# Altium schematic sheet A-Headers.SchDoc(A-Headers)
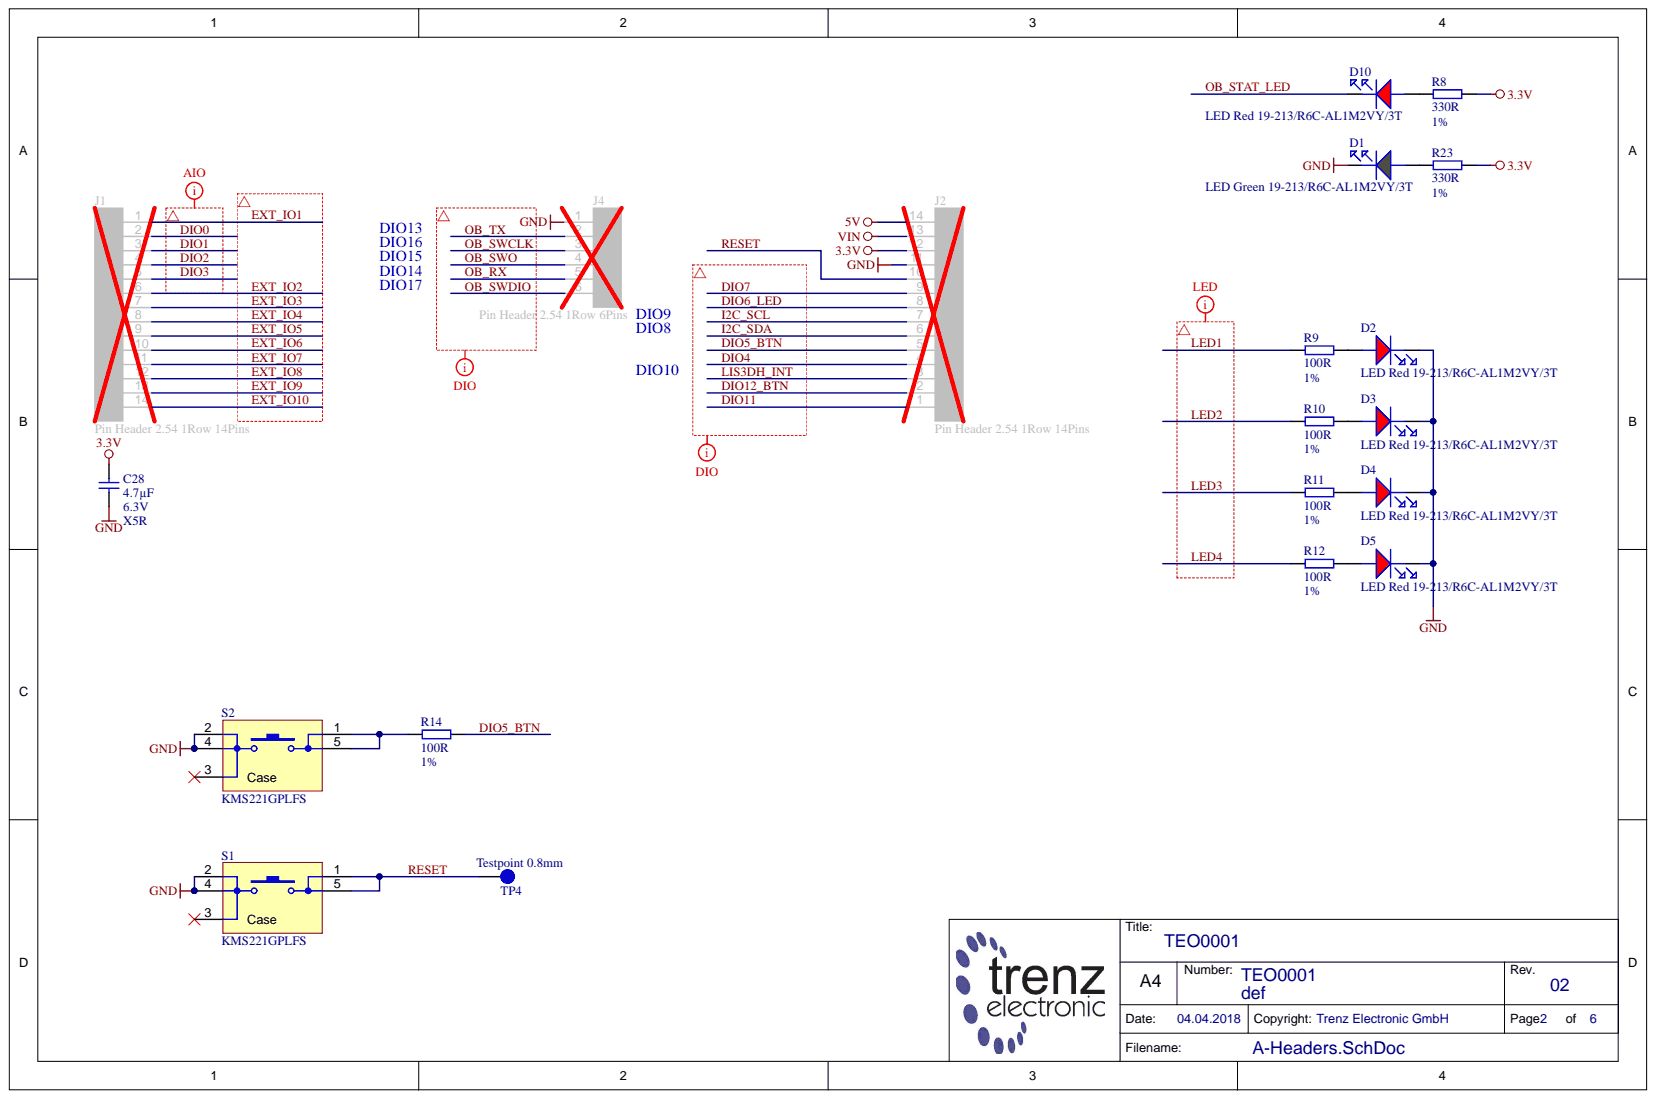
[diagram p0: A-Headers.SchDoc]

## Parts
C28  4.7µF  Technology: X5R  Voltage: 6.3V  [CAPC1005X60N]
D1  LED Green 19-213/R6C-AL1M2VY/3T  [LEDC1608X55N_GREEN]
D2  LED Red 19-213/R6C-AL1M2VY/3T  Database Table Name: LED  H-ART: 19-213/R6C-AL1M2VY/3T  [LEDC1608X55N_RED]
D3  LED Red 19-213/R6C-AL1M2VY/3T  Database Table Name: LED  H-ART: 19-213/R6C-AL1M2VY/3T  [LEDC1608X55N_RED]
D4  LED Red 19-213/R6C-AL1M2VY/3T  Database Table Name: LED  H-ART: 19-213/R6C-AL1M2VY/3T  [LEDC1608X55N_RED]
D5  LED Red 19-213/R6C-AL1M2VY/3T  Database Table Name: LED  H-ART: 19-213/R6C-AL1M2VY/3T  [LEDC1608X55N_RED]
D10  LED Red 19-213/R6C-AL1M2VY/3T  H-ART: 19-213/R6C-AL1M2VY/3T  [LEDC1608X55N_RED]
J1  Pin Header 2.54 1Row 14Pins  [STIFTLEISTE2_54 OG 1R-14]
J2  Pin Header 2.54 1Row 14Pins  [STIFTLEISTE2_54 OG 1R-14]
J4  Pin Header 2.54 1Row 6Pins  [STIFTLEISTE2_54 OG 1R-6]
R8  330R  Tolerance: 1%  [RESC1005X40N]
R9  100R  Tolerance: 1%  [RESC1005X40N]
R10  100R  Tolerance: 1%  [RESC1005X40N]
R11  100R  Tolerance: 1%  [RESC1005X40N]
R12  100R  Tolerance: 1%  [RESC1005X40N]
R14  100R  Tolerance: 1%  [RESC1005X40N]
R23  330R  Tolerance: 1%  [RESC1005X40N]
S1  KMS221GPLFS  [KMS2xxGPLFS]
S2  KMS221GPLFS  [KMS2xxGPLFS]
TP4  Testpoint 0.8mm  [TP_0.8mm]

## Nets
3.3V: C28.1 J2.12 R8.2 R23.2
5V: J2.14
GND: C28.2 D1.K D2.K D3.K D4.K D5.K J2.11 J4.1 S1.2 S1.4 S2.2 S2.4
VIN: J2.13
DIO0: J1.2
DIO1: J1.3
DIO2: J1.4
DIO3: J1.5
DIO4: J2.4
DIO5_BTN: J2.5 R14.2
DIO6_LED: J2.8
DIO7: J2.9
DIO11: J2.1
DIO12_BTN: J2.2
EXT_IO1: J1.1
EXT_IO2: J1.6
EXT_IO3: J1.7
EXT_IO4: J1.8
EXT_IO5: J1.9
EXT_IO6: J1.10
EXT_IO7: J1.11
EXT_IO8: J1.12
EXT_IO9: J1.13
EXT_IO10: J1.14
I2C_SCL: J2.7
I2C_SDA: J2.6
LED1: R9.1
LED2: R10.1
LED3: R11.1
LED4: R12.1
LIS3DH_INT: J2.3
OB_RX: J4.5
OB_STAT_LED: D10.K
OB_SWCLK: J4.3
OB_SWDIO: J4.6
OB_SWO: J4.4
OB_TX: J4.2
RESET: J2.10 S1.1 S1.5 TP4.1
NetD1_A: D1.A R23.1
NetD2_A: D2.A R9.2
NetD3_A: D3.A R10.2
NetD4_A: D4.A R11.2
NetD5_A: D5.A R12.2
NetD10_A: D10.A R8.1
NetR14_1: R14.1 S2.1 S2.5
N00031:
N00032:
N00033:
N00034:

Not connected: S1 3; S2 3

## Library data of the parts
C28 = 24719: KON 4.7µF 6.3V X5R SMD 20%; Database Table Name: C_1005_0402; Mixed: SMT; Preferred: Yes; Value: 4.7u; AN: 24719; Case: 1005 (0402); Component Kind: Standard; Library Name: TE.DbLib; Tolerance: 20%
D1 = 28262: Chip LED 0603 Briliant Yellow Green; H-ART: 19-213/G6C-BM1N2/DT; Mixed: SMT; AN: 28262; Case: 1608 (0603); Component Kind: Standard; Database Table Name: LED; Library Name: TE.DbLib; HelpURL: b:\AD\adlib\datasheet\19-213-G6C-BM1\
D2-D5 D10 = 28261: Chip LED 0603 Briliant Red; Mixed: SMT; AN: 28261; Case: 1608 (0603); Component Kind: Standard; Library Name: TE.DbLib; HelpURL: b:\AD\adlib\datasheet\19-213-R6C\
  D10: Database Table Name: LED
J1 J2 = 27961: Stiftleiste gerade 1-reihig, 14pol.; Database Table Name: CON_HEADER; Mixed: THT; AN: 27961; Component Kind: Standard; Library Name: TE.DbLib; HelpURL: b:\AD\adlib\datasheet\W+P_943_944_945\
J4 = 28042: Stiftleiste gerade 1-reihig, 6pol; Database Table Name: CON_HEADER; Mixed: THT; AN: 28042; Component Kind: Standard; Library Name: TE.DbLib
R8 R23 = 22762: WID SMD 330R 1% 0,063W; Database Table Name: R_1005_0402; Mixed: SMT; Preferred: Yes; Value: 330; AN: 22762; Case: 1005 (0402); Component Kind: Standard; Library Name: TE.DbLib; Technology: TK100
R9-R12 R14 = 22507: WID SMD 100R 1% 0,063W; Database Table Name: R_1005_0402; Mixed: SMT; Preferred: Yes; Value: 100; AN: 22507; Case: 1005 (0402); Component Kind: Standard; Library Name: TE.DbLib; Technology: TK100
S1 S2 = 28549: Database Table Name: PB_SW; Mixed: SMT; AN: 28549; Component Kind: Standard; Description: KMS221GPLFS; H-ART: KMS221GPLFS; Library Name: TE.DbLib; HelpURL: B:\AD\adlib\datasheet\KMS
TP4 = 26289: Database Table Name: PCB; Mixed: MECH; AN: 26289; Component Kind: Standard; Description: Testpoint 0.8mm; Library Name: TE.DbLib
